# Altium schematic sheet 遥控器_V1_0_C.SchDoc(遥控器_V1_0_C)
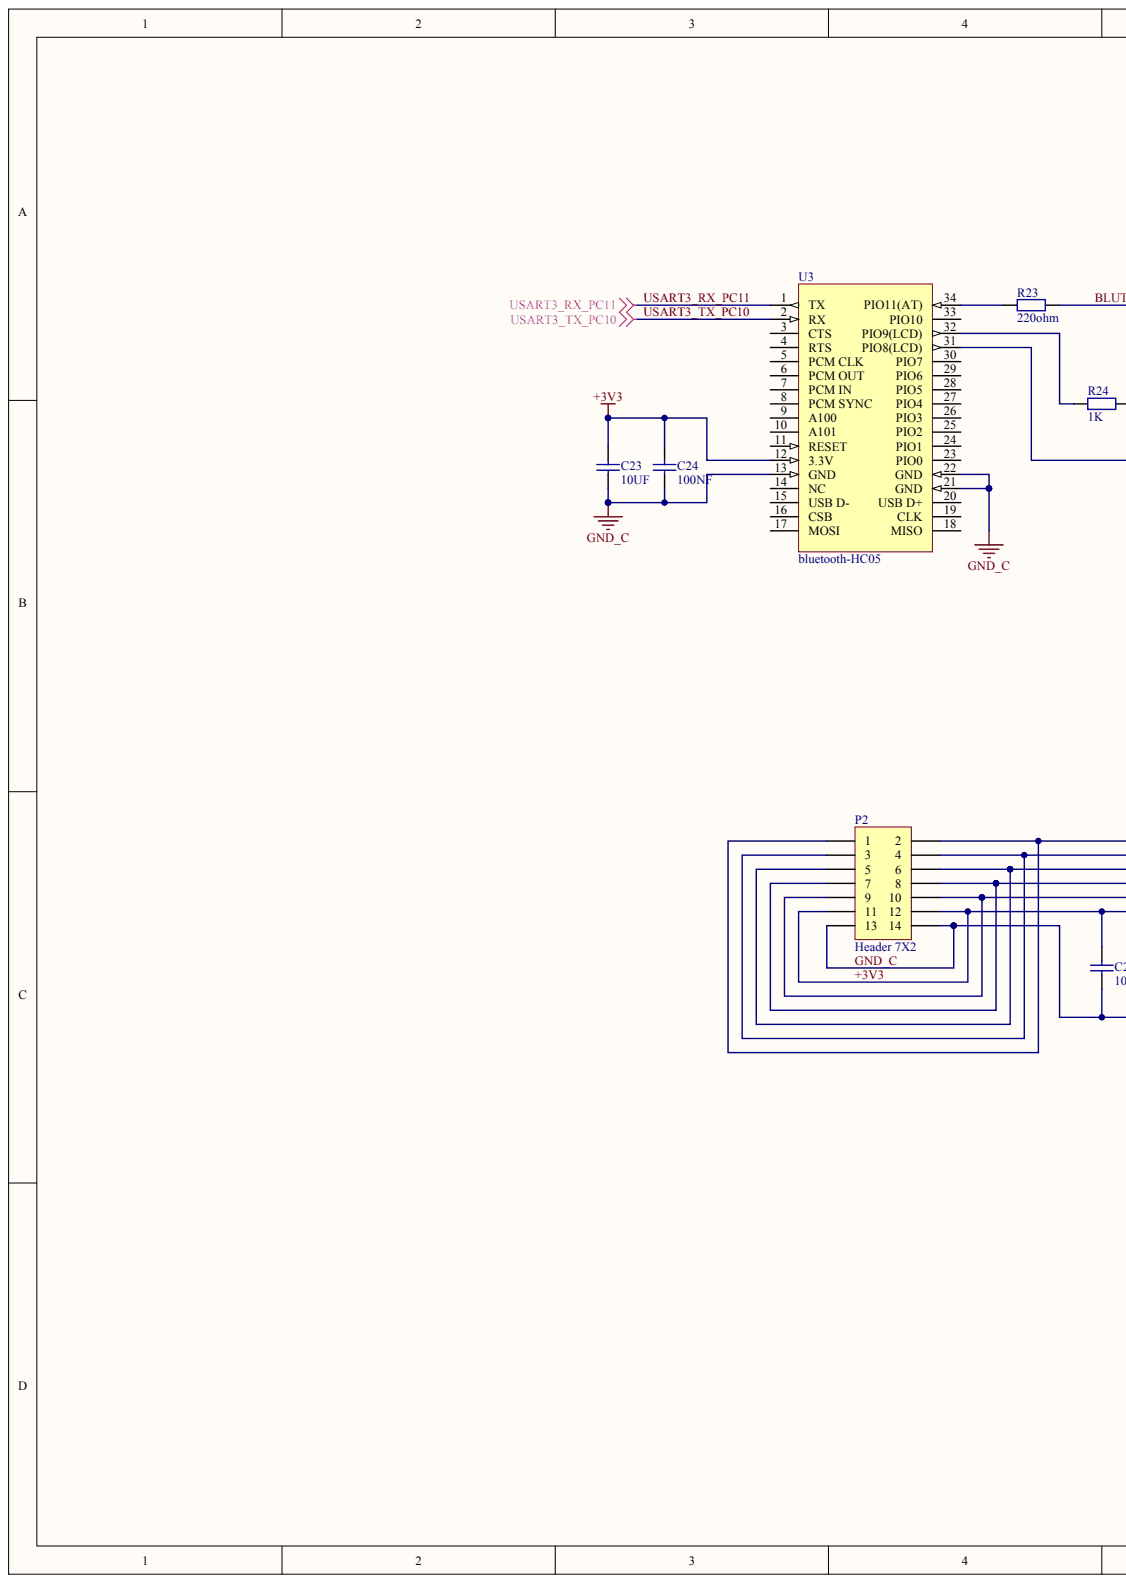
[diagram p0: 遥控器_V1_0_C.SchDoc, left]
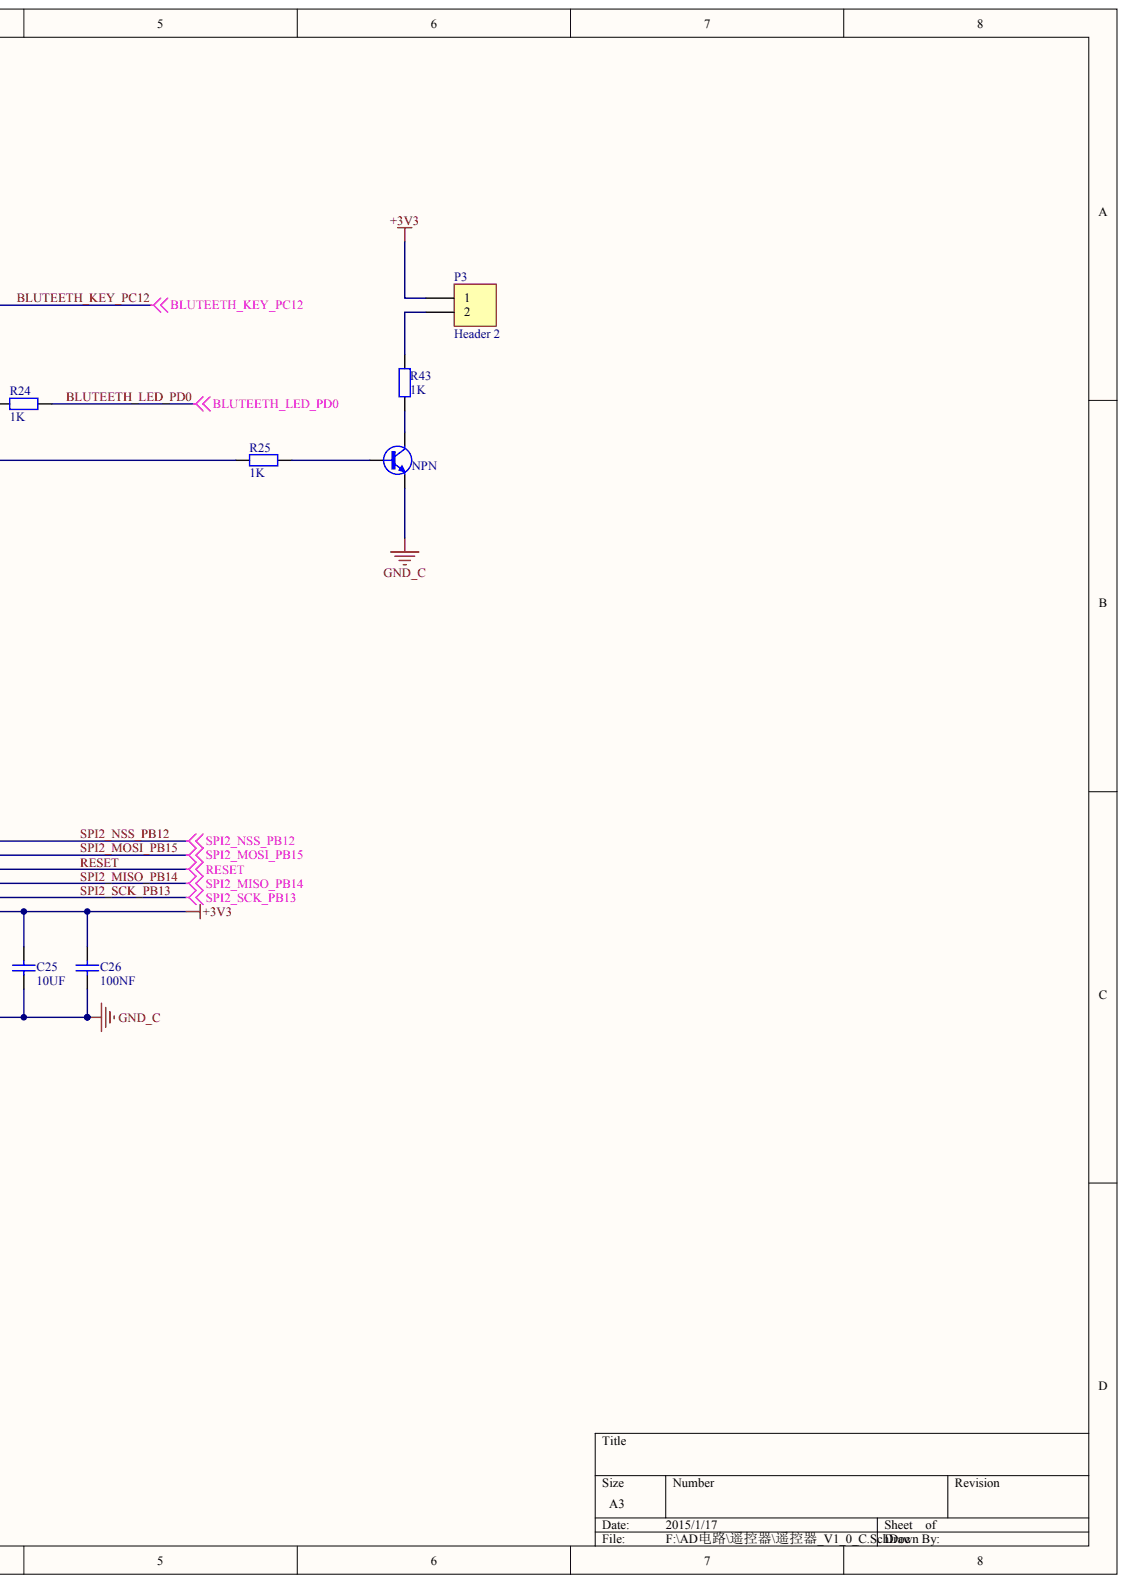
[diagram p1: 遥控器_V1_0_C.SchDoc, right]

## Parts
B5  NPN
C23  10UF
C24  100NF
C25  10UF
C26  100NF
P2  Header 7X2
P3  Header 2
R23  220ohm
R24  1K
R25  1K
R43  1K
U3  bluetooth-HC05

## Nets
+3V3: C23.2 C24.2 C25.2 C26.2 P2.11 P2.12 P3.1 U3.12(3.3V)
BLUTEETH_KEY_PC12: R23.1
BLUTEETH_LED_PD0: R24.2
GND_C: B5.2 C23.1 C24.1 C25.1 C26.1 P2.13 P2.14 U3.13(GND) U3.21(GND) U3.22(GND)
RESET: P2.5 P2.6
SPI2_MISO_PB14: P2.7 P2.8
SPI2_MOSI_PB15: P2.3 P2.4
SPI2_NSS_PB12: P2.1 P2.2
SPI2_SCK_PB13: P2.9 P2.10
USART3_RX_PC11: U3.1(TX)
USART3_TX_PC10: U3.2(RX)
NetB5_1: B5.1 R25.1
NetB5_3: B5.3 R43.1
NetP3_2: P3.2 R43.2
NetR23_2: R23.2 U3.34(PIO11(AT))
NetR24_1: R24.1 U3.32(PIO9(LCD))
NetR25_2: R25.2 U3.31(PIO8(LCD))

Not connected: U3 3(CTS) 4(RTS) 5(PCM_CLK) 6(PCM_OUT) 7(PCM_IN) 8(PCM_SYNC) 9(A100) 10(A101) 11(RESET) 14(NC) 15(USB_D-) 16(CSB) 17(MOSI) 18(MISO) 19(CLK) 20(USB_D+) 23(PIO0) 24(PIO1) 25(PIO2) 26(PIO3) 27(PIO4) 28(PIO5) 29(PIO6) 30(PIO7) 33(PIO10)

## Library data of the parts
C23 C25 = (no symbol name): value: 100NF
R23 = (no symbol name): value: 1K
B5 C24 C26 P2 P3 R24 R25 R43 U3 = (no symbol name)
  C24 C26: value: 100NF
  P2 P3: LatestRevisionDate: 17-Jul-2002; LatestRevisionNote: Re-released for DXP Platform.; Publisher: Altium Limited
  R24 R25 R43: value: 1K
